# Altium schematic sheet i2ckeypad.SchDoc
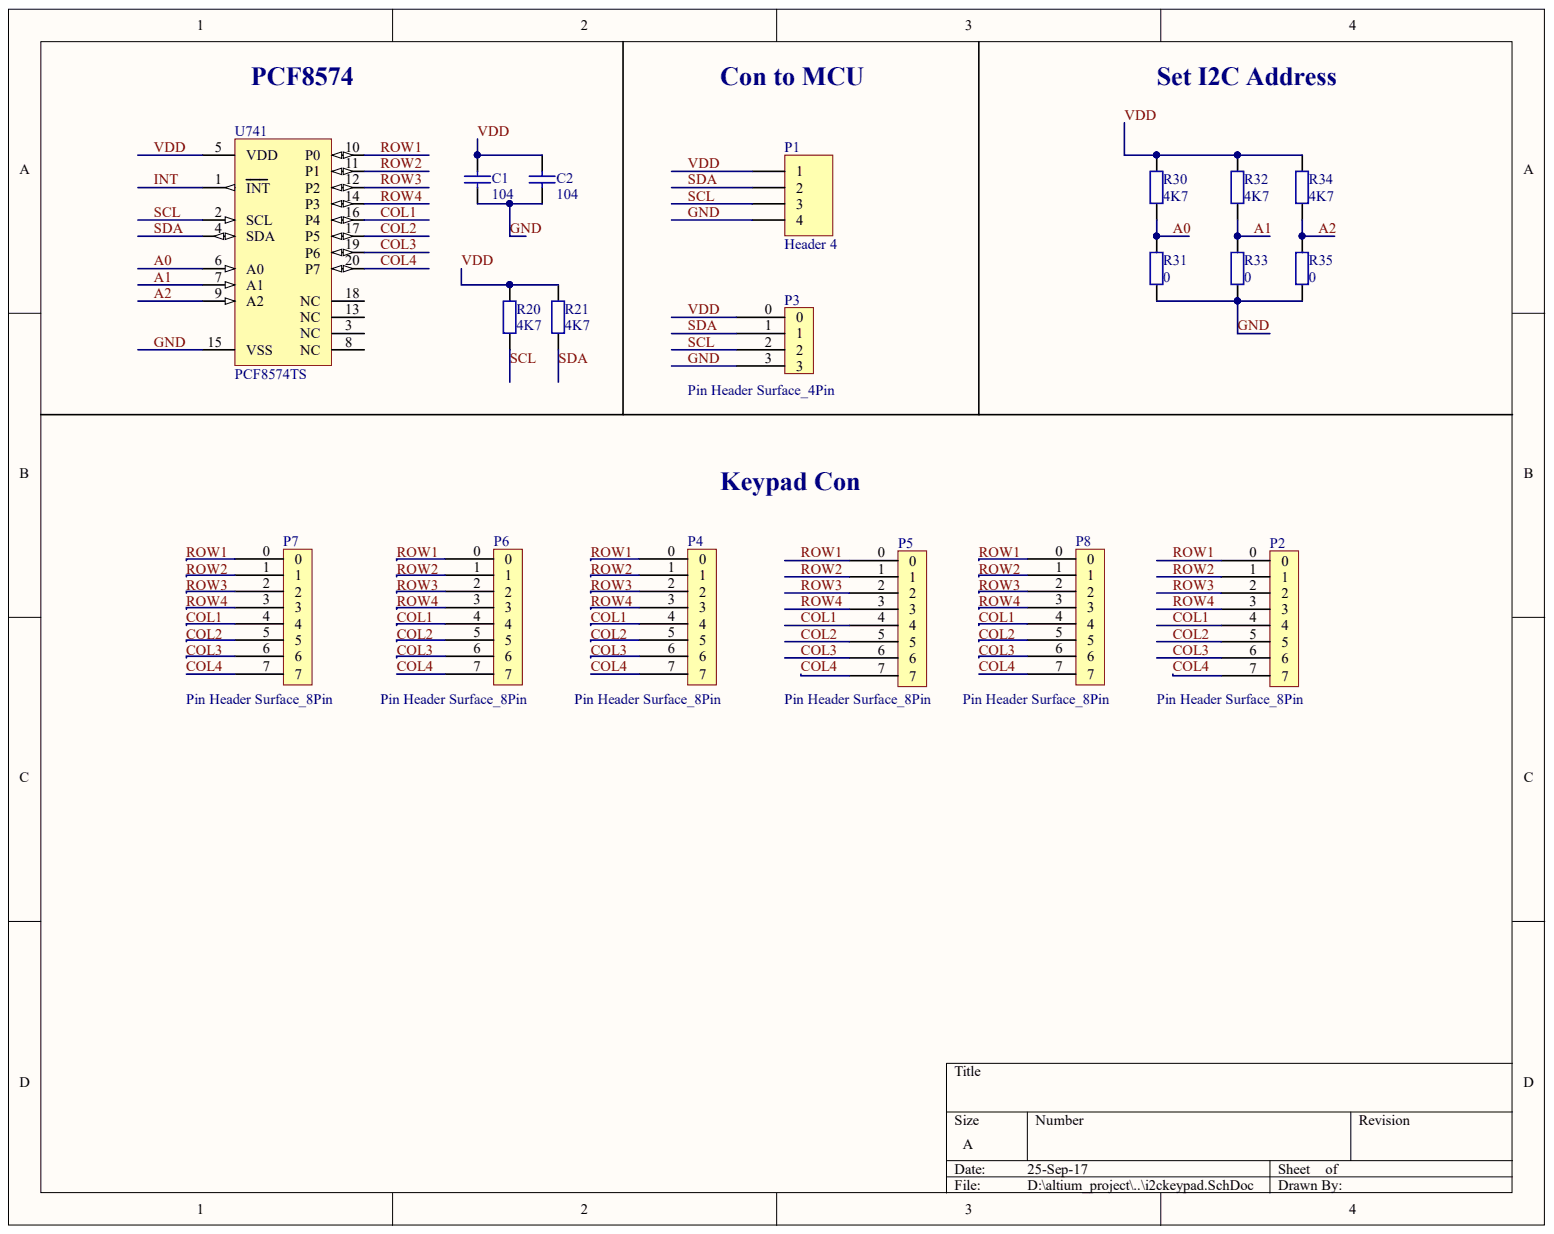
[diagram p0: i2ckeypad.SchDoc]

## Parts
C1  104  [IPC7351_EC_0603]
C2  104  [IPC7351_EC_0603]
P1  Header 4  [HDR1X4]
P2  Pin Header Surface_8Pin  [Pin Header Surface_8Pin]
P3  Pin Header Surface_4Pin  [Pin Header Surface_4pin]
P4  Pin Header Surface_8Pin  [Pin Header Surface_8Pin]
P5  Pin Header Surface_8Pin  [Pin Header Surface_8Pin]
P6  Pin Header Surface_8Pin  [Pin Header Surface_8Pin]
P7  Pin Header Surface_8Pin  [Pin Header Surface_8Pin]
P8  Pin Header Surface_8Pin  [Pin Header Surface_8Pin]
R20  4K7  [IPC7351_ER_0603]
R21  4K7  [IPC7351_ER_0603]
R30  4K7  [IPC7351_ER_0603]
R31  0  [IPC7351_ER_0603]
R32  4K7  [IPC7351_ER_0603]
R33  0  [IPC7351_ER_0603]
R34  4K7  [IPC7351_ER_0603]
R35  0  [IPC7351_ER_0603]
U741  PCF8574TS  [SOP65P640-20AM]

## Nets
A0: R30.2 R31.1 U741.6(A0)
A1: R32.2 R33.1 U741.7(A1)
A2: R34.2 R35.1 U741.9(A2)
COL1: P2.4 P4.4 P5.4 P6.4 P7.4 P8.4 U741.16(P4)
COL2: P2.5 P4.5 P5.5 P6.5 P7.5 P8.5 U741.17(P5)
COL3: P2.6 P4.6 P5.6 P6.6 P7.6 P8.6 U741.19(P6)
COL4: P2.7 P4.7 P5.7 P6.7 P7.7 P8.7 U741.20(P7)
GND: C1.1 C2.1 P1.4 P3.3 R31.2 R33.2 R35.2 U741.15(VSS)
INT: U741.1(INT)
ROW1: P2.0 P4.0 P5.0 P6.0 P7.0 P8.0 U741.10(P0)
ROW2: P2.1 P4.1 P5.1 P6.1 P7.1 P8.1 U741.11(P1)
ROW3: P2.2 P4.2 P5.2 P6.2 P7.2 P8.2 U741.12(P2)
ROW4: P2.3 P4.3 P5.3 P6.3 P7.3 P8.3 U741.14(P3)
SCL: P1.3 P3.2 R20.2 U741.2(SCL)
SDA: P1.2 P3.1 R21.2 U741.4(SDA)
VDD: C1.2 C2.2 P1.1 P3.0 R20.1 R21.1 R30.1 R32.1 R34.1 U741.5(VDD)

Not connected: U741 3(NC) 8(NC) 13(NC) 18(NC)

## Library data of the parts
C1 C2 = Cap Semi: Capacitor 0603; MFG: KEMET; MFG P/N: C0603C104J5RACTU; Component Kind: Standard; Library Name: Miscellaneous Devices.IntLib; Price: 0.6; Signal Integrity: Cap Semi; Simulation: CAP; Supplier: ES
P1 = Header 4: Header, 4-Pin; Component Kind: Standard; LatestRevisionDate: 17-Jul-2002; LatestRevisionNote: Re-released for DXP Platform.; Library Name: Miscellaneous Connectors.IntLib; Signal Integrity: Connector; Publisher: Altium Limited
R20 R21 R30-R35 = Res2: Resistor 0603; MFG: YAGEO; MFG P/N: RC0603FR-074K7L; Component Kind: Standard; Library Name: Miscellaneous Devices.IntLib; Price: 0.4; Signal Integrity: Res; Simulation: RESISTOR; Supplier: Digikey
U741 = PCF8574TS: Remote 8-bit I/O expander for I2C-bus with interrupt; Component Kind: Standard; Library Name: Misc.SchLib; Supplier1: Digikey; Supplier1 P/N: PCF8574TS/3,118; Supplier1 Price: 34.93
P2 P4-P8 = Pin Header Surface_8Pin: Component Kind: Standard; Library Name: STK_FOOT_new.SchLib
P3 = Pin Header Surface_4Pin: Component Kind: Standard; Library Name: STK_FOOT_new.SchLib
